# Altium schematic sheet Sensor_Proteses.SchDoc(Sensor_Proteses)
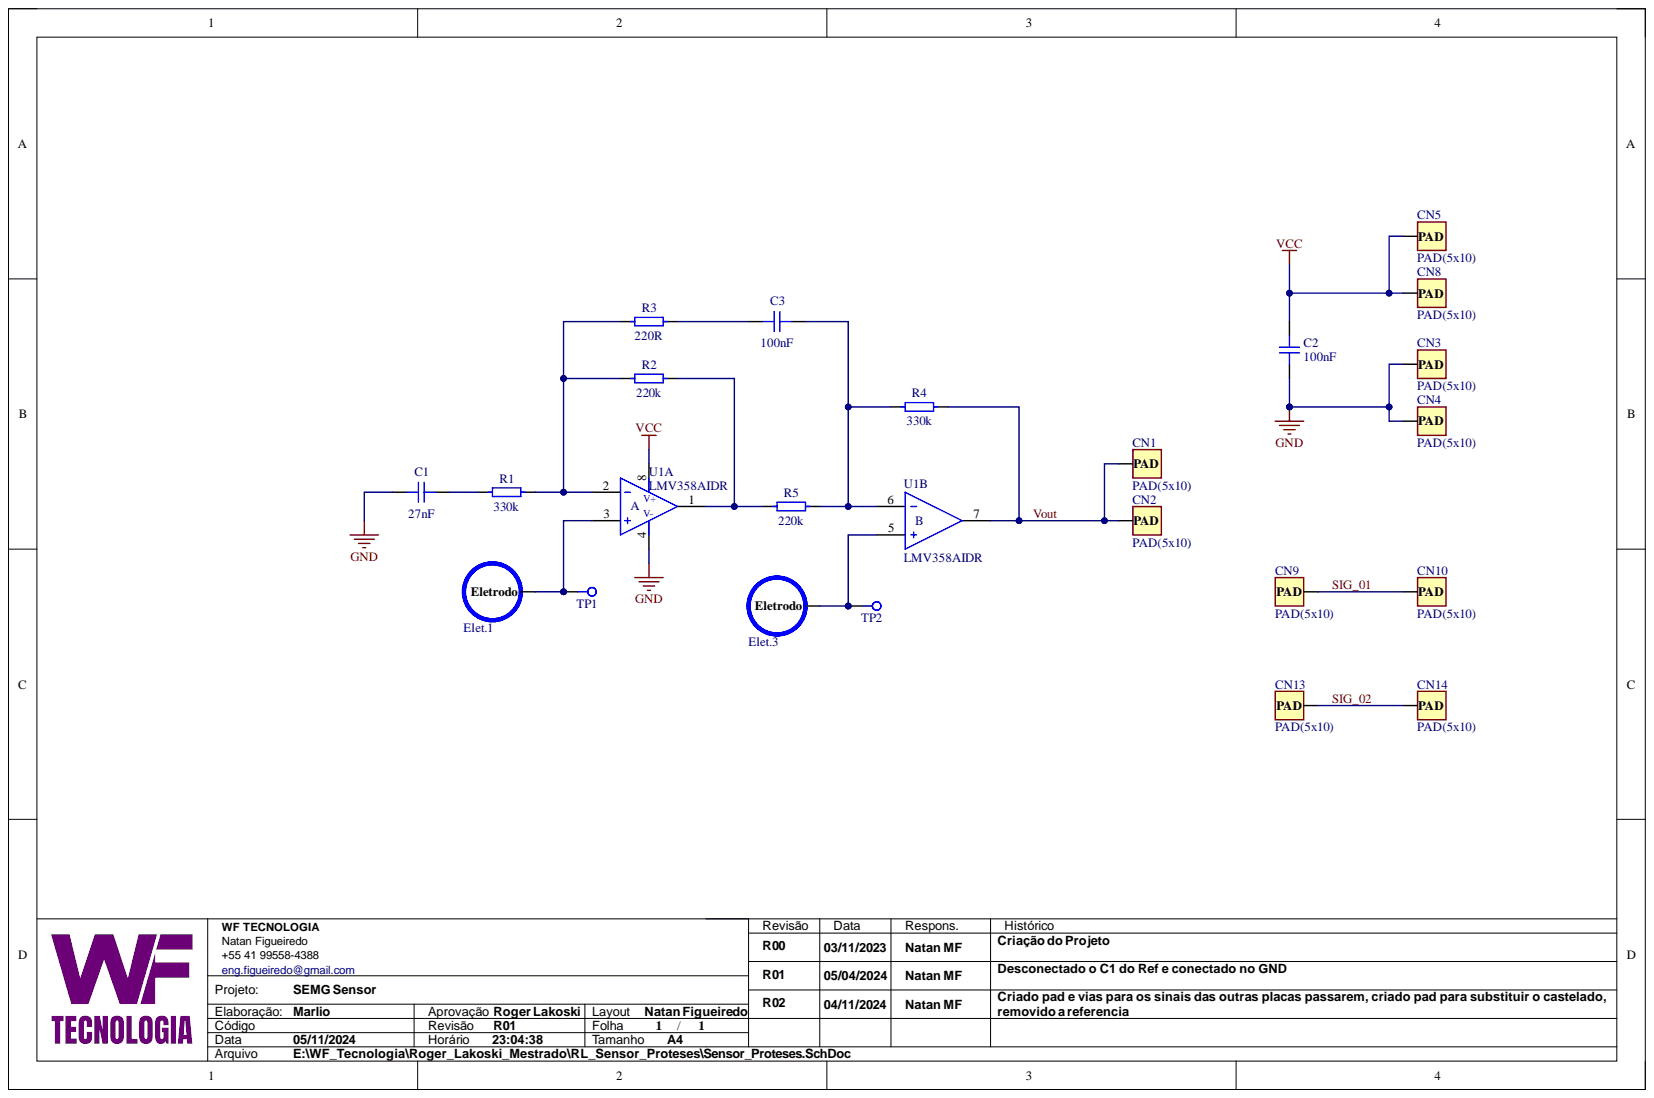
[diagram p0: Sensor_Proteses.SchDoc]

## Parts
C1  27nF  [SMD_C_0603]
C2  100nF  [SMD_C_0603]
C3  100nF  [SMD_C_1206]
CN1  PAD(5x10)  [PAD(1.5x2.0)]  (no BOM)
CN2  PAD(5x10)  [PAD(1.5x2.0)]  (no BOM)
CN3  PAD(5x10)  [PAD(1.5x2.0)]  (no BOM)
CN4  PAD(5x10)  [PAD(1.5x2.0)]  (no BOM)
CN5  PAD(5x10)  [PAD(1.5x2.0)]  (no BOM)
CN8  PAD(5x10)  [PAD(1.5x2.0)]  (no BOM)
CN9  PAD(5x10)  [PAD(1.5x2.0)]  (no BOM)
CN10  PAD(5x10)  [PAD(1.5x2.0)]  (no BOM)
CN13  PAD(5x10)  [PAD(1.5x2.0)]  (no BOM)
CN14  PAD(5x10)  [PAD(1.5x2.0)]  (no BOM)
Elet.1  Circuito_Eletroudo  [Eletroudo]  (value not drawn)  (no BOM)
Elet.3  Circuito_Eletroudo  [Eletroudo]  (value not drawn)  (no BOM)
R1  330k  [SMD_R0603_F]
R2  220k  [SMD_R0603_F]
R3  220R  [SMD_R0603_F]
R4  330k  [SMD_R0603_F]
R5  220k  [SMD_R0603_F]
TP1  TP BP  [TP_PTH_BP]  (value not drawn)
TP2  TP BP  [TP_PTH_BP]  (value not drawn)
U1  LMV358AIDR  [SOIC-8]  (parts A,B)

## Nets
GND: C1.1 C2.2 CN3.P CN4.P U1.4(V-)
VCC: C2.1 CN5.P CN8.P U1.8(V+)
SIG_01: CN9.P CN10.P
SIG_02: CN13.P CN14.P
Vout: CN1.P CN2.P R4.1 U1.7
NetC1_2: C1.2 R1.1
NetC3_1: C3.1 R3.2
NetC3_2: C3.2 R4.2 R5.2 U1.6
NetElet.1_0: Elet.1.0 TP1.0 U1.3
NetElet.3_0: Elet.3.0 TP2.0 U1.5
NetR1_2: R1.2 R2.1 R3.1 U1.2
NetR2_2: R2.2 R5.1 U1.1

## Library data of the parts
C1 = Cap_Cer._0.027uF_10%_25V_SMD(0603): 0.027 µF ±10% 25V Ceramic Capacitor X7R 0603 (1608 Metric); Manufacturer: Cal-Chip Electronics, Inc.; Part Number: GMC10X7R273K25NT; Type: SMD; Component Kind: Standard; ComponentLink1Description: Datasheet 1; ComponentLink1URL: https://calchip.com/wp-content/uploads/2023/09/GMC-Series-2.pdf; Size: 0603; Supplier 1: Digi-Key; Supplier Part Number 1: 2571-GMC10X7R273K25NTTR-ND; Value: 27nF; Library Name: Bib_LOTUS.IntLib
C2 = Cap_Cer._100nF_10%_50V_SMD(0603): 0.1 µF ±10% 50V Ceramic Capacitor X7R 0603 (1608 Metric); Manufacturer: TDK Corporation; Part Number: C1608X7R1H104K080AA; CDM: C10416; Type: SMD; Component Kind: Standard; ComponentLink1Description: Datasheet 1; ComponentLink1URL: https://product.tdk.com/system/files/dam/doc/product/capacitor/ceramic/mlcc/catalog/mlcc_commercial_general_en.pdf; Size: 0603; Supplier 1: Digi-Key; Supplier Part Number 1: 445-1314-2-ND; Value: 100nF; Library Name: Bib_LOTUS.IntLib
C3 = Cap_Cer._100nF_±10%_50V_X7R_SMD(1206): 0.1 µF ±10% 50V Capacitor de cerâmica X7R 1206 (métrico 3216); Manufacturer: KEMET; Part Number: C1206C104K5RAC7800; Type: SMD; Component Kind: Standard; ComponentLink1Description: Datasheet 1; ComponentLink1URL: https://content.kemet.com/datasheets/KEM_C1002_X7R_SMD.pdf; Size: 1206; Supplier 1: Digi-Key; Supplier Part Number 1: 399-C1206C104K5RAC7800TR-ND; Value: 100nF; Library Name: Bib_LOTUS.IntLib
CN1-CN5 CN8-CN10 CN13 CN14 = Conn_1Vias_180°_PAD(1.5x2.0): Pad sem furo, pra solda  (1.5x2.0),; System: Connector; ComponentLink1Description: Datasheet 1; Size: PAD(5x10); Type: SMD; Value: PAD(5x10); Library Name: Bib_LOTUS.IntLib
R1 R4 = RES_330k_1%_0.1W_SMD(0603): 330 kOhms ±1% 0.1W, 1/10W Chip Resistor 0603 (1608 Metric) Moisture Resistant Thick Film; Manufacturer: YAGEO; Part Number: RC0603FR-07330KL; CDM: C12147; Component Kind: Standard; ComponentLink1Description: DataSheet; ComponentLink1URL: https://www.yageo.com/upload/media/product/app/datasheet/rchip/pyu-rc_group_51_rohs_l.pdf; Size: 0603; Supplier 1: Digi-Key; Supplier Part Number 1: 311-330KHRTR-ND; Type: SMD; Value: 330k; Library Name: Bib_LOTUS.IntLib
R2 R5 = RES_220k_1%_0.1W_SMD(0603): 220 kOhms ±1% 0.1W, 1/10W Chip Resistor 0603 (1608 Metric) Moisture Resistant Thick Film; Manufacturer: YAGEO; Part Number: RC0603FR-07220KL; CDM: C10444; Component Kind: Standard; ComponentLink1Description: DataSheet; ComponentLink1URL: https://www.yageo.com/upload/media/product/app/datasheet/rchip/pyu-rc_group_51_rohs_l.pdf; Size: 0603; Supplier 1: Digi-Key; Supplier Part Number 1: 311-220KHRTR-ND; Type: SMD; Value: 220k; Library Name: Bib_LOTUS.IntLib
R3 = RES_220R_1%_0.1W_SMD(0603): 220 Ohms ±1% 0.1W, 1/10W Chip Resistor 0603 (1608 Metric) Moisture Resistant Thick Film; Manufacturer: YAGEO; Part Number: RC0603FR-07220RL; CDM: C10420; Component Kind: Standard; ComponentLink1Description: DataSheet; ComponentLink1URL: https://www.yageo.com/upload/media/product/productsearch/datasheet/rchip/PYu-RC_Group_51_RoHS_L_12.pdf; Size: 0603; Supplier 1: Digi-Key; Supplier Part Number 1: 311-220HRTR-ND; Type: SMD; Value: 220R; Library Name: Bib_LOTUS.IntLib
TP1 TP2 = TP_PTH_BP: Barra de Pino; CDM: C11994; Component Kind: Standard; ComponentLink1Description: Datasheet 1; Supplier 1: Digi-Key; Value: TP BP; Library Name: Bib_LOTUS.IntLib
U1 = Amp_LMV358AIDR: Uso geral Amplificador 2 Circuito Rail-to-Rail 8-SOIC; Manufacturer: Texas Instruments; Component Kind: Standard; ComponentLink1Description: Datasheet 1; ComponentLink1URL: http://www.ti.com/general/docs/suppproductinfo.tsp?distId=10&gotoUrl=http%3A%2F%2Fwww.ti.com%2Flit%2Fgpn%2Flmv358a; Part Number: LMV358AIDR; Size: SOIC-8; Supplier 1: Digi-Key; Supplier Part Number 1: 296-47593-2-ND; Type: SMD; Value: LMV358AIDR; Library Name: Bib_LOTUS.IntLib
Elet.1 Elet.3 = Circuito_Eletroudo: Library Name: Sensor_Proteses.SCHLIB
